# Altium schematic sheet Programmer 2.SchDoc
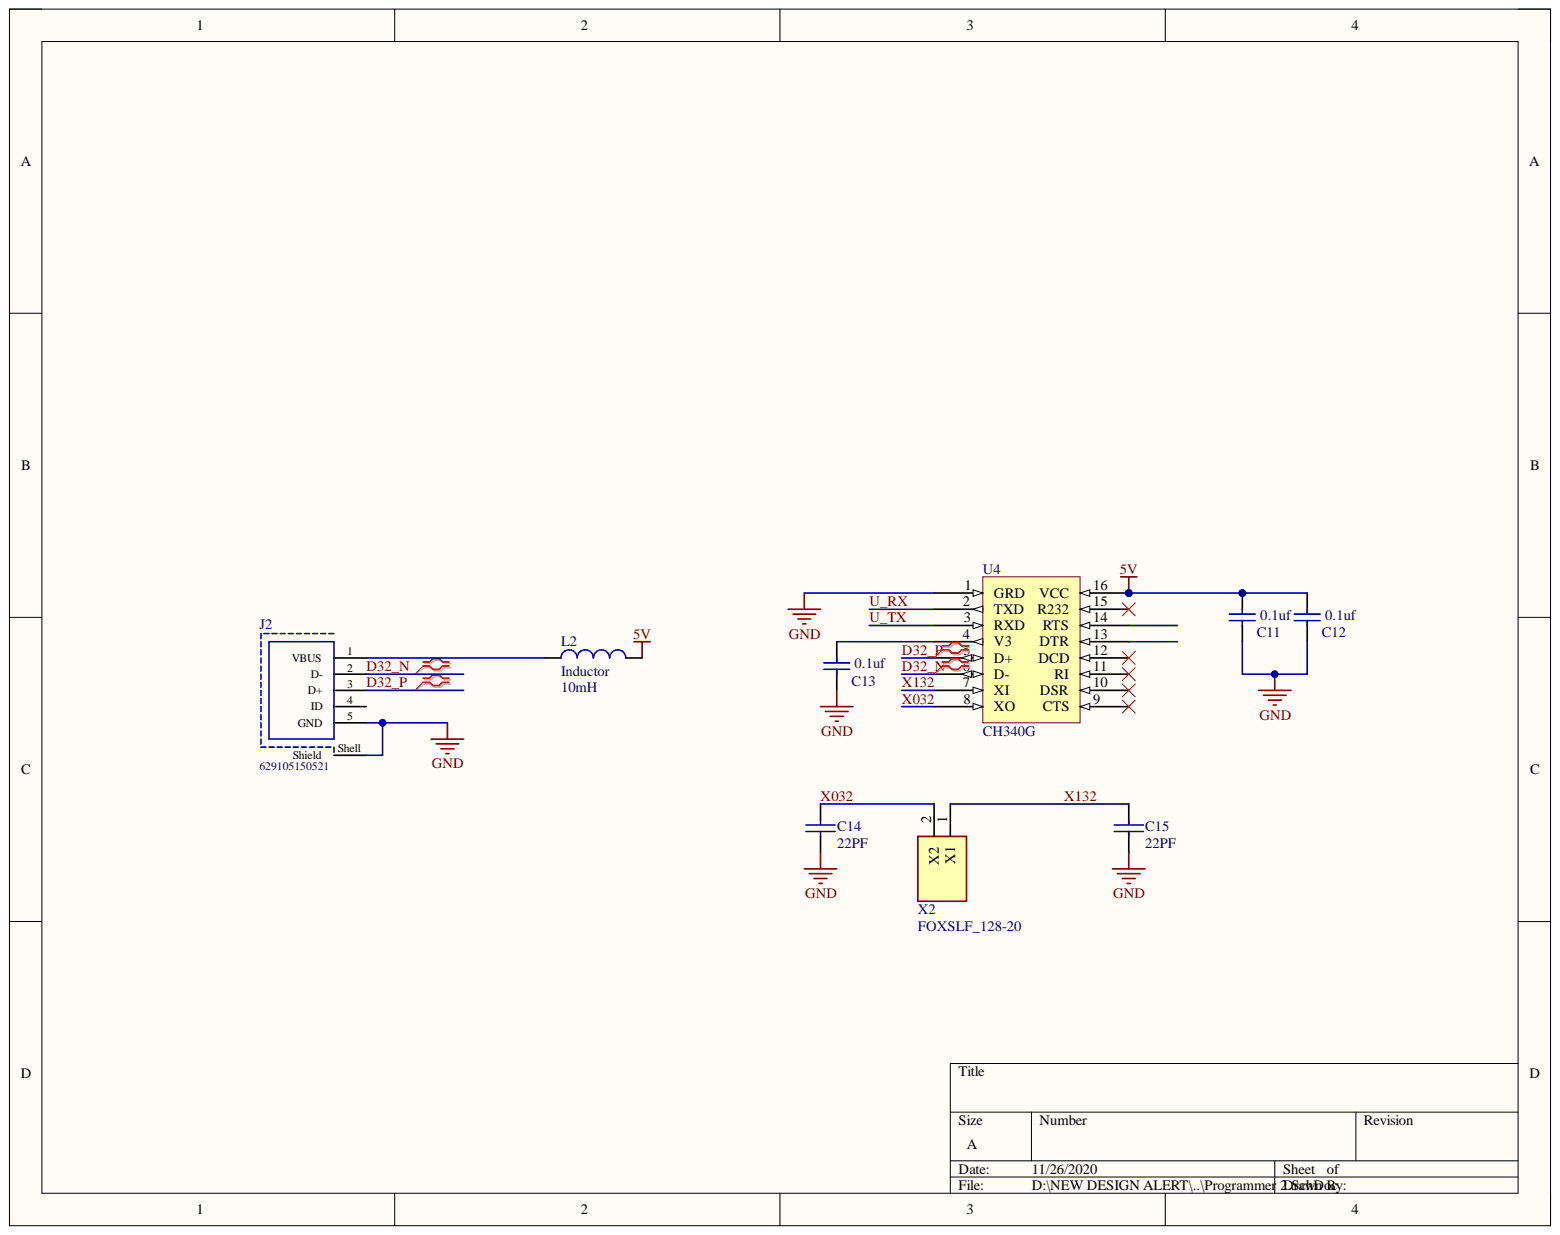
[diagram p0: Programmer 2.SchDoc]

## Parts
C11  0.1uf  [CAPC0603]
C12  0.1uf  [CAPC0603]
C13  0.1uf  [CAPC0603]
C14  22PF  [CAPC0603]
C15  22PF  [CAPC0603]
J2  629105150521  [HRS_ZX62D-B-5P8]
L2  Inductor  value 10mH  [CAPC0805]
U4  CH340G  [SOIC16_N]
X2  FOXSLF_128-20  [FOXSLF12820]

## Nets
5V: C11.1 C12.1 L2.2 U4.16(VCC)
GND: C11.2 C12.2 C13.2 C14.1 C15.1 J2.5(GND) J2.Shell(Shield) U4.1(GRD)
D32_N: J2.2(D-) U4.6(D-)
D32_P: J2.3(D+) U4.5(D+)
U_RX: U4.2(TXD)
U_TX: U4.3(RXD)
X032: C14.2 U4.8(XO) X2.2
X132: C15.2 U4.7(XI) X2.1
NetC13_1: C13.1 U4.4(V3)
NetJ2_1: J2.1(VBUS) L2.1

Not connected: J2 4(ID); U4 9(CTS) 10(DSR) 11(RI) 12(DCD) 13(DTR) 14(RTS) 15(R232)

## Library data of the parts
C11-C13 = CMP-2007-04765-1: Manufacturer: AVX Interconnect / Elco; Part Number: 08055C104KAJ2A; Component Kind: Standard; Library Name: Miscellaneous Devices.IntLib
C14 C15 = CAP NP: Component Kind: Standard; Library Name: Devices.SchLib
J2 = CMP-1502-03252-1: Micro USB 2.0 Type B Receptacle WR-COM, Horizontal, SMT, with Pegs, High Current at Pin 1 & Pin 5; Manufacturer: Wurth Elektronik; Contact Resistance: 30mOhm; Rated Current: 3A; Working Voltage: 30VAC; Component Kind: Standard; Connector Type: MicroUSB2.0TypeB; Datasheet URL: https://katalog.we-online.de/em/datasheet/629105150521.pdf; Gender: Receptacle; Library Name: FT2232HL.Library.IntLib; Manufacturer URL: http://www.we-online.de; Mounting Technology: SMT; Operating Temperature: -40to85degC; Orientation: Horizontal; Package Reference: 629105150521; Packaging: TapeandReel; Part Number: 629105150521; Publisher: WurthElektronik
L2 = Inductor: Code_IEC: 1005; Code_JEITA: 0402; Component Kind: Standard; Description: Inductor; LatestRevisionDate: 29-May-2009; LatestRevisionNote: IPC-7351 Footprint Added.; Library Name: Miscellaneous Devices.IntLib; PackageDescription: Chip Inductor; PackageReference: 0402-A; Published: 8-Jun-2000; Signal Integrity: Inductor; Simulation: INDUCTOR; Publisher: Altium Limited
X2 = FOXSLF_128-20: Crystal or Oscillator; Manufacturer_Name: Fox; Manufacturer_Part_Number: FOXSLF/128-20; Arrow Part Number: FOXSLF/128-20; Arrow Price/Stock: https://www.arrow.com/en/products/foxslf128-20/fox-electronics; Component Kind: Standard; Datasheet Link: https://componentsearchengine.com/Datasheets/2/FOXSLF_128-20.pdf; Height: 3.6mm; Mouser Part Number: 559-FOXS128-20-LF; Mouser Price/Stock: https://www.mouser.co.uk/ProductDetail/Fox/FOXSLF-128-20?qs=mzRxyRlhVdtRySSKq5R9SA%3D%3D
U4 = CH340G: Component Kind: Standard; Library Name: CH340.Schlib1.SchLib
